# Altium schematic sheet [10] - USB-JTAG, USB-UART.SchDoc("[10] - USB-JTAG, USB-UART")
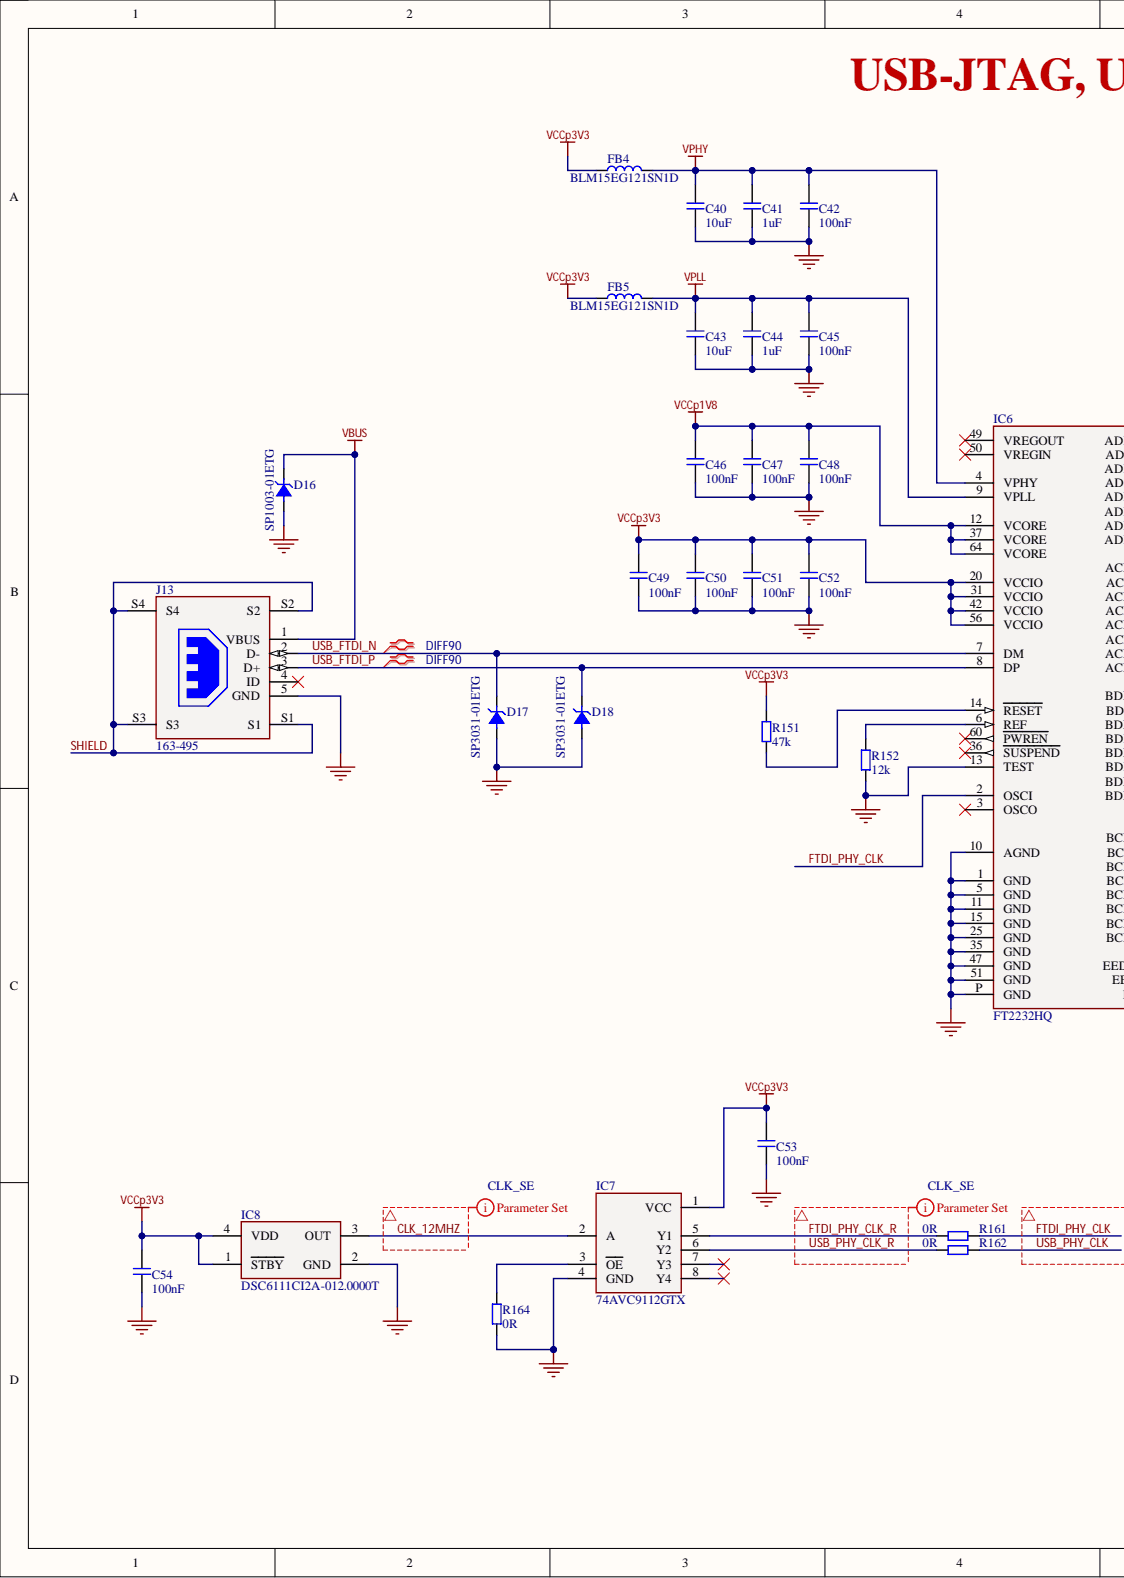
[diagram p0: [10] - USB-JTAG, USB-UART.SchDoc, left]
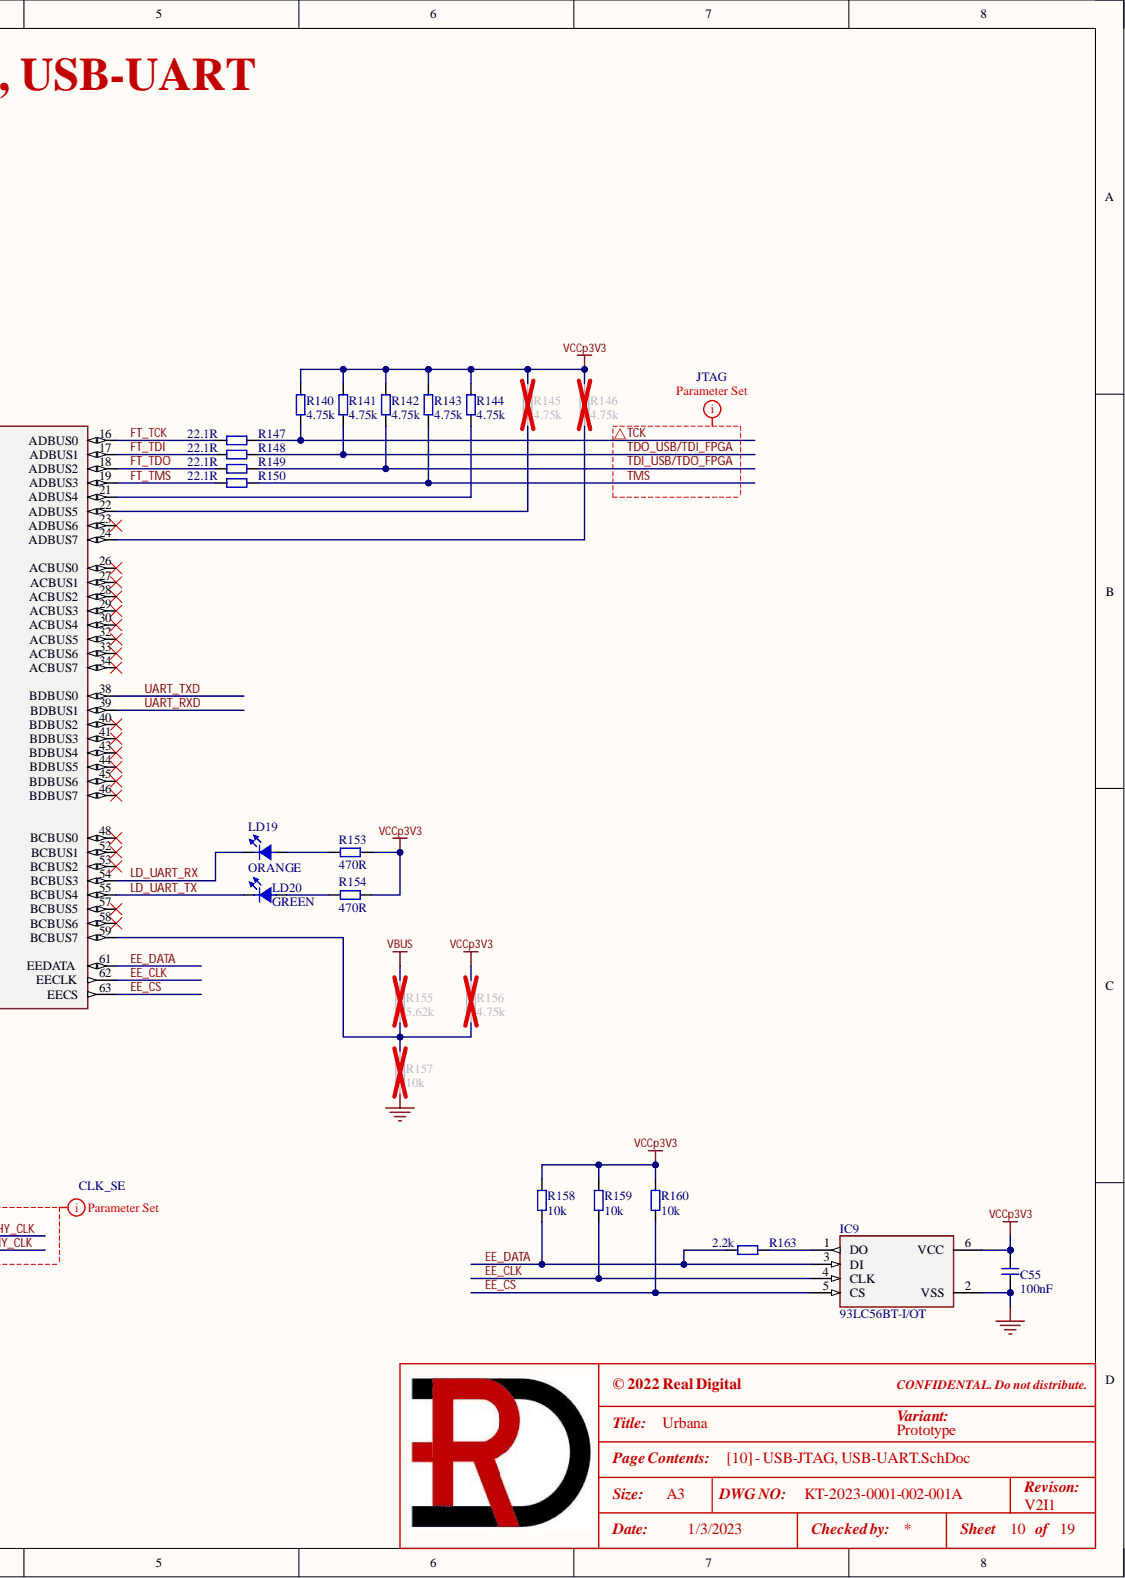
[diagram p1: [10] - USB-JTAG, USB-UART.SchDoc, right]

## Parts
C40  10uF  [C0805]
C41  1uF  [C0603]
C42  100nF  [C0402]
C43  10uF  [C0805]
C44  1uF  [C0603]
C45  100nF  [C0402]
C46  100nF  [C0402]
C47  100nF  [C0402]
C48  100nF  [C0402]
C49  100nF  [C0402]
C50  100nF  [C0402]
C51  100nF  [C0402]
C52  100nF  [C0402]
C53  100nF  [C0402]
C54  100nF  [C0402]
C55  100nF  [C0402]
D16  SP1003-01ETG  [SOD882 - LITTLEFUSE]
D17  SP3031-01ETG  [SOD882 - LITTLEFUSE]
D18  SP3031-01ETG  [SOD882 - LITTLEFUSE]
FB4  BLM15EG121SN1D  [BLM15]
FB5  BLM15EG121SN1D  [BLM15]
IC6  FT2232HQ  [QFN64 - FTDI]
IC7  74AVC9112GTX  [XSON8 - NEXPERIA]
IC8  DSC6111CI2A-012.0000T  [DSC3.2x2.5-4]
IC9  93LC56BT-I/OT  [SOT23-6 - Microchip]
J13  163-495  [163-495]
LD19  ORANGE  [LD0603_ORANGE]
LD20  GREEN  [LD0603_Green]
R140  4.75k  [R0402]
R141  4.75k  [R0402]
R142  4.75k  [R0402]
R143  4.75k  [R0402]
R144  4.75k  [R0402]
R145  4.75k  [R0402]
R146  4.75k  [R0402]
R147  22.1R  [R0402]
R148  22.1R  [R0402]
R149  22.1R  [R0402]
R150  22.1R  [R0402]
R151  47k  [R0402]
R152  12k  [R0402]
R153  470R  [R0402]
R154  470R  [R0402]
R155  5.62k  [R0402]
R156  4.75k  [R0402]
R157  10k  [R0402]
R158  10k  [R0402]
R159  10k  [R0402]
R160  10k  [R0402]
R161  0R  [R0402]
R162  0R  [R0402]
R163  2.2k  [R0402]
R164  0R  [R0402]

## Nets
GND: C40.1 C41.1 C42.1 C43.1 C44.1 C45.1 C46.1 C47.1 C48.1 C49.1 C50.1 C51.1 C52.1 C53.1 C54.1 C55.1 D16.A D17.A D18.A IC6.1(GND) IC6.5(GND) IC6.10(AGND) IC6.11(GND) IC6.13(TEST) IC6.15(GND) IC6.25(GND) IC6.35(GND) IC6.47(GND) IC6.51(GND) IC6.P(GND) IC7.4(GND) IC8.2(GND) IC9.2(VSS) J13.5(GND) R152.1 R157.1 R164.1
VBUS: D16.K J13.1(VBUS) R155.2
VCCp1V8: C46.2 C47.2 C48.2 IC6.12(VCORE) IC6.37(VCORE) IC6.64(VCORE)
VCCp3V3: C49.2 C50.2 C51.2 C52.2 C53.2 C54.2 C55.2 FB4.1 FB5.1 IC6.20(VCCIO) IC6.31(VCCIO) IC6.42(VCCIO) IC6.56(VCCIO) IC7.1(VCC) IC8.1(STBY) IC8.4(VDD) IC9.6(VCC) R140.2 R141.2 R142.2 R143.2 R144.2 R145.2 R146.2 R151.2 R153.2 R154.2 R156.2 R158.2 R159.2 R160.2
VPHY: C40.2 C41.2 C42.2 FB4.2 IC6.4(VPHY)
VPLL: C43.2 C44.2 C45.2 FB5.2 IC6.9(VPLL)
CLK_12MHZ: IC7.2(A) IC8.3(OUT)
EE_CLK: IC6.62(EECLK) IC9.4(CLK) R159.1
EE_CS: IC6.63(EECS) IC9.5(CS) R160.1
EE_DATA: IC6.61(EEDATA) IC9.3(DI) R158.1 R163.1
FT_TCK: IC6.16(ADBUS0) R147.1
FT_TDI: IC6.17(ADBUS1) R148.1
FT_TDO: IC6.18(ADBUS2) R149.1
FT_TMS: IC6.19(ADBUS3) R150.1
FTDI_PHY_CLK: IC6.2(OSCI) R161.1
FTDI_PHY_CLK_R: IC7.5(Y1) R161.2
LD_UART_RX: IC6.54(BCBUS3) LD19.K
LD_UART_TX: IC6.55(BCBUS4) LD20.K
SHIELD: J13.S1 J13.S2 J13.S3 J13.S4
TCK: R140.1 R147.2
TDI_USB/TDO_FPGA: R142.1 R149.2
TDO_USB/TDI_FPGA: R141.1 R148.2
TMS: R143.1 R150.2
UART_RXD: IC6.39(BDBUS1)
UART_TXD: IC6.38(BDBUS0)
USB_FTDI_N: D17.K IC6.7(DM) J13.2(D-)
USB_FTDI_P: D18.K IC6.8(DP) J13.3(D+)
USB_PHY_CLK: R162.1
USB_PHY_CLK_R: IC7.6(Y2) R162.2
NetIC6_6: IC6.6(REF) R152.2
NetIC6_14: IC6.14(RESET) R151.1
NetIC6_21: IC6.21(ADBUS4) R144.1
NetIC6_22: IC6.22(ADBUS5) R145.1
NetIC6_24: IC6.24(ADBUS7) R146.1
NetIC6_59: IC6.59(BCBUS7) R155.1 R156.1 R157.2
NetIC7_3: IC7.3(OE) R164.2
NetIC9_1: IC9.1(DO) R163.2
NetLD19_A: LD19.A R153.1
NetLD20_A: LD20.A R154.1

Not connected: IC6 3(OSCO) 23(ADBUS6) 26(ACBUS0) 27(ACBUS1) 28(ACBUS2) 29(ACBUS3) 30(ACBUS4) 32(ACBUS5) 33(ACBUS6) 34(ACBUS7) 36(SUSPEND) 40(BDBUS2) 41(BDBUS3) 43(BDBUS4) 44(BDBUS5) 45(BDBUS6) 46(BDBUS7) 48(BCBUS0) 49(VREGOUT) 50(VREGIN) 52(BCBUS1) 53(BCBUS2) 57(BCBUS5) 58(BCBUS6) 60(PWREN); IC7 7(Y3) 8(Y4); J13 4(ID)

## Library data of the parts
C40 C43 = GRM21BR71A106KA73L: CAP CER 10uF 10V X7R 0805; Manufacturer 1: Murata Electronics; .Checked_PCB: AC; .Creator: RV; C P/N: 111-0789; LoadStatus: Load; Tolerance: 10%; Category: Capacitors; Component Kind: Standard; Library Name: KT-RealDigital.IntLib; Manufacturer 1 Part Number: GRM21BR71A106KA73L; Temperature Coefficient: X7R; Voltage - Rated: 10V; .Checked_SCH: AC
C41 C44 = GCJ188R71E105KA01D: CAP CER 1uF 25V X7R 0603; Manufacturer 1: Murata Electronics; .Checked_PCB: AC; .Creator: RV; C P/N: 111-0602; LoadStatus: Load; Tolerance: 10%; Category: Capacitors; Component Kind: Standard; Library Name: KT-RealDigital.IntLib; Manufacturer 1 Part Number: GCJ188R71E105KA01D; Temperature Coefficient: X7R; Voltage - Rated: 25V; .Checked_SCH: AC
C42 C45-C55 = GRM155R71E104KE14J: CAP CER 0.1uF 25V X7R 0402; Manufacturer 1: Murata Electronics; .Checked_PCB: AC; .Creator: RV; C P/N: RD-111-0006; LoadStatus: Load; Tolerance: 10%; Category: Capacitors; Component Kind: Standard; Library Name: KT-RealDigital.IntLib; Manufacturer 1 Part Number: GRM155R71E104KE14J; Temperature Coefficient: X7R; Voltage - Rated: 25V; .Checked_SCH: AC
D16 = SP1003-01ETG: TVS DIODE 5V 12V SOD882; Manufacturer 1: Littelfuse Inc.; .Checked_PCB: RV; LoadStatus: Load; Category: TVS - Diodes; Component Kind: Standard; Library Name: KT-RealDigital.IntLib; Manufacturer 1 Part Number: SP1003-01ETG; .Checked_SCH: RV; .Creator: RV
D17 D18 = SP3031-01ETG: TVS DIODE 5V 7.5V SOD882; Manufacturer 1: Littelfuse Inc.; .Checked_PCB: RV; LoadStatus: Load; Category: TVS - Diodes; Component Kind: Standard; Library Name: KT-RealDigital.IntLib; Manufacturer 1 Part Number: SP3031-01ETG; .Checked_SCH: RV; .Creator: RV
FB4 FB5 = BLM15EG121SN1D: FERRITE BEAD 120 OHM 0402 1LN; Manufacturer 1: Murata Electronics; .Checked_PCB: AC; .Creator: RV; C P/N: 131-0493; LoadStatus: Load; Category: Ferrite Beads; Component Kind: Standard; Library Name: KT-RealDigital.IntLib; Manufacturer 1 Part Number: BLM15EG121SN1D; .Checked_SCH: AC
IC6 = FT2232HQ: IC USB HS DUAL UART/FIFO 64-QFN; Manufacturer 1: FTDI; Manufacturer 1 Part Number: FT2232HQ-REEL; .Checked_PCB: AC; .Creator: RV; C P/N: 126-0695; LoadStatus: Load; Category: IC; Component Kind: Standard; Library Name: KT-RealDigital.IntLib; .Checked_SCH: AC
IC7 = 74AVC9112GTX: Clock Fanout Buffer (Distribution) IC 1:4 8-XFDFN; Manufacturer 1: Nexperia USA Inc.; .Checked_PCB: RV; LoadStatus: Load; Category: IC; Component Kind: Standard; Library Name: KT-RealDigital.IntLib; Manufacturer 1 Part Number: 74AVC9112GTX; .Checked_SCH: RV; .Creator: RV
IC8 = DSC6111CI2A-012.0000T: 12MHz XO (Standard) CMOS Oscillator 1.8V ~ 3.3V Standby (Power Down) 4-SMD, No Lead; Manufacturer 1: Microchip; Manufacturer 2 Part Number: SMA-012000-3CY4T1; .Checked_PCB: AC; .Creator: RV; C P/N: 126-1425; LoadStatus: Load; Category: Oscillators; Component Kind: Standard; Library Name: KT-RealDigital.IntLib; Manufacturer 1 Part Number: DSC6111CI2A-012.0000T; Manufacturer 2: AKER TECHNOLOGY CO., LTD.; .Checked_SCH: AC
IC9 = 93LC56BT-I/OT: 2K Microwire Compatible Serial EEPROM; Manufacturer 1: Microchip; .Checked_PCB: AC; .Creator: RV; C P/N: 126-0703; LoadStatus: Load; Category: IC; Component Kind: Standard; Library Name: KT-RealDigital.IntLib; Manufacturer 1 Part Number: 93LC56BT-I/OT; .Checked_SCH: AC
J13 = 163-495: CONN RCPT USB2.0 MICRO B SMD R/A; .Checked_PCB: RV; C P/N: 163-0495; LoadStatus: Load; Category: Connectors; Component Kind: Standard; Library Name: KT-RealDigital.IntLib; Manufacturer 1 Part Number: 163-495; .Checked_SCH: RV; .Creator: RV
LD19 = LED_ORANGE: LED ORANGE 0603; Manufacturer 1: Victory Electronics; Manufacturer 1 Part Number: VS 28A8M; .Checked_PCB: AC; .Creator: RV; C P/N: 121-1275; LoadStatus: Load; Category: Led; Component Kind: Standard; Library Name: KT-RealDigital.IntLib; .Checked_SCH: AC
LD20 = LED_GREEN: LED GREEN 0603; Manufacturer 1: Everlight Electronics CO., LTD; Manufacturer 1 Part Number: 19-21SYGC/S530-E4/TR8; .Checked_PCB: AC; .Creator: RV; C P/N: 121-0006; LoadStatus: Load; Category: Led; Component Kind: Standard; Library Name: KT-RealDigital.IntLib; .Checked_SCH: AC
R140-R146 R156 = RC0402FR-074K75P: RES SMD 4.75K OHM 1% 1/16W 0402; Manufacturer 1: Yageo; .Checked_PCB: AC; .Creator: RV; C P/N: 101-0124; LoadStatus: Load; Category: Resistors; Component Kind: Standard; Library Name: KT-RealDigital.IntLib; Manufacturer 1 Part Number: RC0402FR-074K75P; Tolerance: 1%; .Checked_SCH: AC
R147-R150 = RC0402FR-0722R1L: RES SMD 22.1 OHM 1% 1/16W 0402; Manufacturer 1: Yageo; .Checked_PCB: AC; .Creator: RV; C P/N: RD-101-0002; LoadStatus: Load; Category: Resistors; Component Kind: Standard; Library Name: KT-RealDigital.IntLib; Manufacturer 1 Part Number: RC0402FR-0722R1L; Tolerance: 1%; .Checked_SCH: AC
R151 = RC0402JR-0747KL: RES SMD 47K OHM 5% 1/16W 0402; Manufacturer 1: Yageo; .Checked_PCB: AC; .Creator: RV; C P/N: 101-0074; LoadStatus: Load; Category: Resistors; Component Kind: Standard; Library Name: KT-RealDigital.IntLib; Manufacturer 1 Part Number: RC0402JR-0747KL; Tolerance: 5%; .Checked_SCH: AC
R152 = RC0402FR-0712KL: RES SMD 12K OHM 1% 1/16W 0402; Manufacturer 1: Yageo; .Checked_PCB: AC; .Creator: RV; C P/N: 101-0643; LoadStatus: Load; Category: Resistors; Component Kind: Standard; Library Name: KT-RealDigital.IntLib; Manufacturer 1 Part Number: RC0402FR-0712KL; Tolerance: 1%; .Checked_SCH: AC
R153 R154 = RC0402JR-07470RL: RES SMD 470 OHM 5% 1/16W 0402; Manufacturer 1: Yageo; .Checked_PCB: AC; .Creator: RV; C P/N: 101-0052; LoadStatus: Load; Category: Resistors; Component Kind: Standard; Library Name: KT-RealDigital.IntLib; Manufacturer 1 Part Number: RC0402JR-07470RL; Tolerance: 5%; .Checked_SCH: AC
R155 = RC0402FR-075K62L: RES SMD 5.62K OHM 1% 1/16W 0402; Manufacturer 1: Yageo; .Checked_PCB: AC; .Creator: RV; LoadStatus: Load; Category: Resistors; Component Kind: Standard; Library Name: KT-RealDigital.IntLib; Manufacturer 1 Part Number: RC0402FR-075K62L; Tolerance: 1%; .Checked_SCH: AC
R157-R160 = RC0402JR-0710KL: RES SMD 10K OHM 5% 1/16W 0402; Manufacturer 1: Yageo; .Checked_PCB: AC; .Creator: RV; C P/N: 101-0047; LoadStatus: Load; Category: Resistors; Component Kind: Standard; Library Name: KT-RealDigital.IntLib; Manufacturer 1 Part Number: RC0402JR-0710KL; Tolerance: 5%; .Checked_SCH: AC
R161 R162 R164 = RC0402FR-070RL: RES SMD 0 OHM JUMPER 1/16W 0402; Manufacturer 1: Yageo; .Checked_PCB: AC; .Creator: RV; C P/N: RD-101-0003; LoadStatus: Load; Category: Resistors; Component Kind: Standard; Library Name: KT-RealDigital.IntLib; Manufacturer 1 Part Number: RC0402FR-070RL; Tolerance: Jumper; .Checked_SCH: AC
R163 = RC0402JR-072K2L: RES SMD 2.2K OHM 5% 1/16W 0402; Manufacturer 1: Yageo; .Checked_PCB: AC; .Creator: RV; C P/N: RD-101-0005; LoadStatus: Load; Category: Resistors; Component Kind: Standard; Library Name: KT-RealDigital.IntLib; Manufacturer 1 Part Number: RC0402JR-072K2L; Tolerance: 5%; .Checked_SCH: AC
